# Altium schematic sheet CODEC.SchDoc(CODEC)
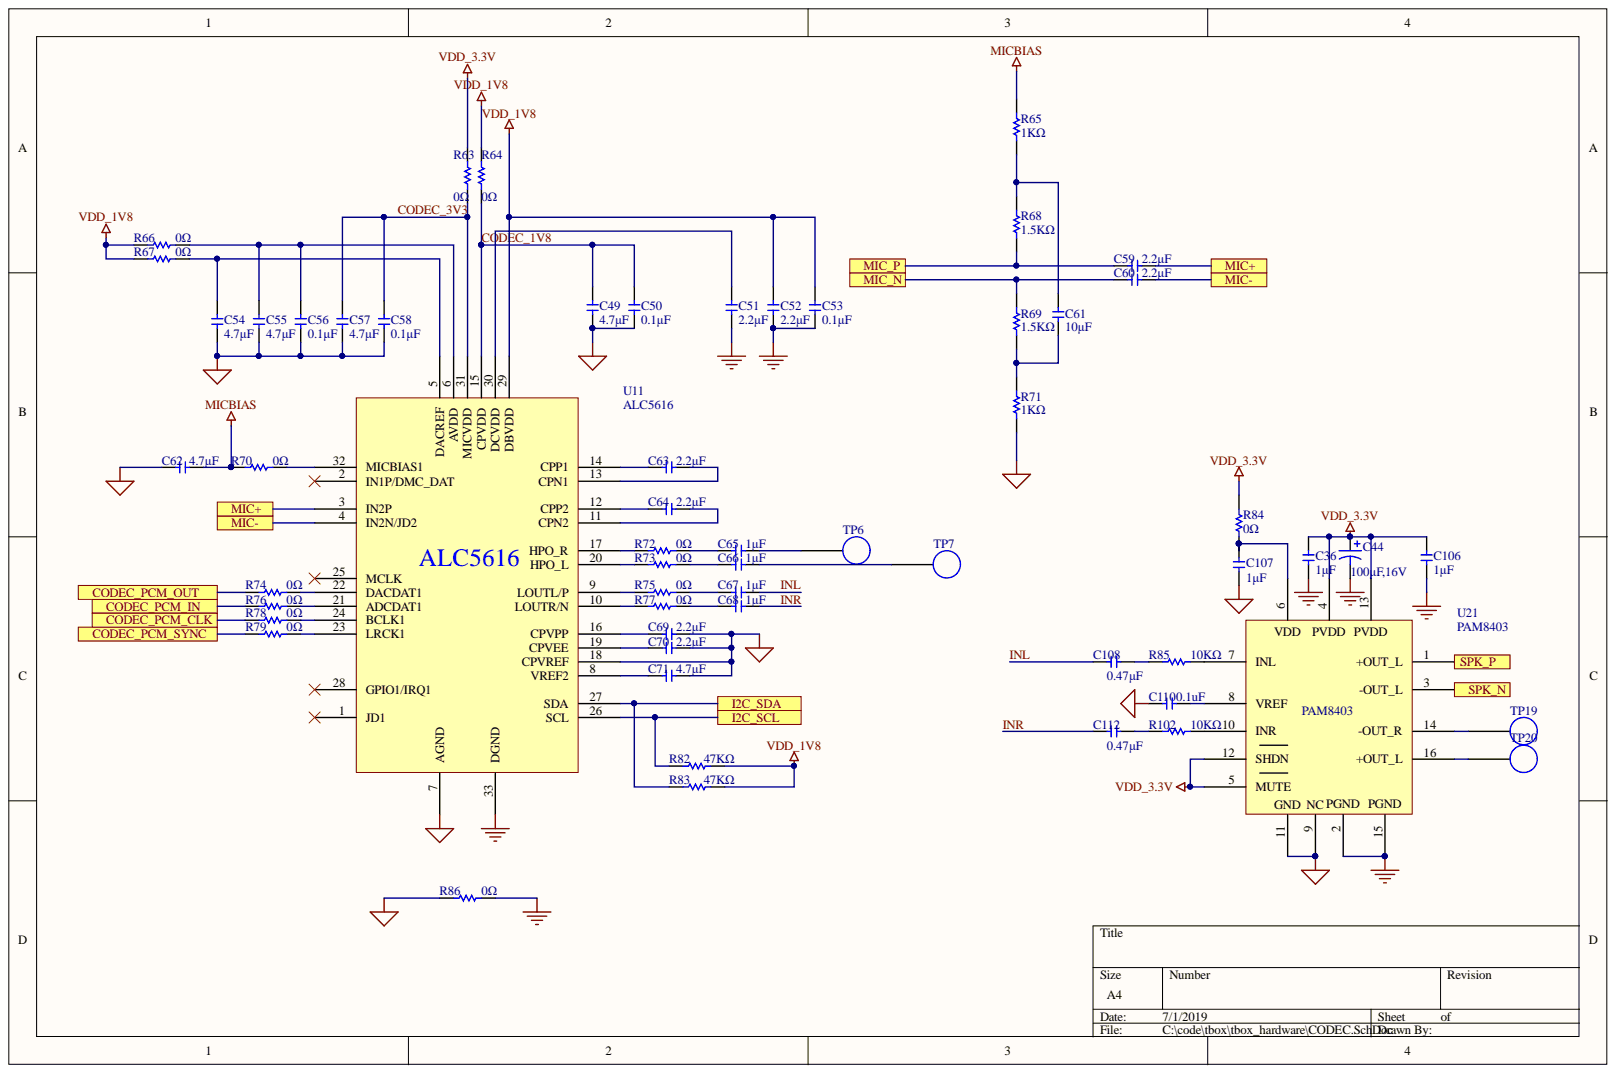
[diagram p0: CODEC.SchDoc]

## Parts
C36  1μF  [C0402]
C44  100μF,16V  [C1210]
C49  4.7μF  [C0402]
C50  0.1μF  [C0402]
C51  2.2μF  [C0402]
C52  2.2μF  [C0402]
C53  0.1μF  [C0402]
C54  4.7μF  [C0402]
C55  4.7μF  [C0402]
C56  0.1μF  [C0402]
C57  4.7μF  [C0402]
C58  0.1μF  [C0402]
C59  2.2μF  [C0402]
C60  2.2μF  [C0402]
C61  10μF  [C0805]
C62  4.7μF  [C0402]
C63  2.2μF  [C0402]
C64  2.2μF  [C0402]
C65  1μF  [C0402]
C66  1μF  [C0402]
C67  1μF  [C0402]
C68  1μF  [C0402]
C69  2.2μF  [C0402]
C70  2.2μF  [C0402]
C71  4.7μF  [C0402]
C106  1μF  [C0402]
C107  1μF  [C0402]
C108  0.47μF  [C0402]
C110  0.1uF  [C0402]
C112  0.47μF  [C0402]
R63  0Ω  [R0402]
R64  0Ω  [R0402]
R65  1KΩ  [R0402]
R66  0Ω  [R0402]
R67  0Ω  [R0402]
R68  1.5KΩ  [R0402]
R69  1.5KΩ  [R0402]
R70  0Ω  [R0402]
R71  1KΩ  [R0402]
R72  0Ω  [R0402]
R73  0Ω  [R0402]
R74  0Ω  [R0402]
R75  0Ω  [R0402]
R76  0Ω  [R0402]
R77  0Ω  [R0402]
R78  0Ω  [R0402]
R79  0Ω  [R0402]
R82  47KΩ  [R0402]
R83  47KΩ  [R0402]
R84  0Ω  [R0402]
R85  10KΩ  [R0402]
R86  0Ω  [R1206]
R102  10KΩ  [R0402]
TP6  TestPoint  [TP]  (value not drawn)
TP7  TestPoint  [TP]  (value not drawn)
TP19  TestPoint  [TP]  (value not drawn)
TP20  TestPoint  [TP]  (value not drawn)
U11  ALC5616  [QFN32_4x4]
U21  PAM8403  [SOP16]

## Ports
CODEC_PCM_CLK, CODEC_PCM_IN, CODEC_PCM_OUT, CODEC_PCM_SYNC, I2C_SCL, I2C_SDA, MIC+, MIC-, MIC_N, MIC_P, SPK_N, SPK_P

## Nets
AGND: C49.1 C50.1 C54.1 C55.1 C56.1 C57.1 C58.1 C62.1 C69.2 C70.2 C71.2 C107.2 C110.1 R71.1 R86.1 U11.7(AGND) U11.18(CPVREF) U21.9(NC) U21.11
GND: C36.2 C44.2 C51.1 C52.1 C53.1 C106.2 R86.2 U11.33(DGND) U21.2 U21.15
MICBIAS: C62.2 R65.2 R70.1
VDD_1V8: C52.2 C53.2 R64.2 R66.1 R67.1 R82.1 R83.1 U11.29(DBVDD)
VDD_3.3V: C36.1 C44.1 C106.1 R63.2 R84.2 U21.4 U21.5(MUTE) U21.12(SHDN) U21.13
CODEC_1V8: C49.2 C50.2 R64.1 U11.15(CPVDD)
CODEC_3V3: C57.2 C58.2 R63.1 U11.31(MICVDD)
CODEC_PCM_CLK: R78.1 ; port CODEC_PCM_CLK
CODEC_PCM_IN: R76.1 ; port CODEC_PCM_IN
CODEC_PCM_OUT: R74.1 ; port CODEC_PCM_OUT
CODEC_PCM_SYNC: R79.1 ; port CODEC_PCM_SYNC
I2C_SCL: R82.2 U11.26(SCL) ; port I2C_SCL
I2C_SDA: R83.2 U11.27(SDA) ; port I2C_SDA
INL: C67.2 C108.1
INR: C68.2 C112.1
MIC_N: C60.2 R69.2 ; port MIC_N
MIC_P: C59.2 R68.1 ; port MIC_P
SPK_N: U21.3(-OUT_L) ; port SPK_N
SPK_P: U21.1(+OUT_L) ; port SPK_P
NetC51_2: C51.2 U11.30(DCVDD)
NetC54_2: C54.2 R67.2 U11.5(DACREF)
NetC55_2: C55.2 C56.2 R66.2 U11.6(AVDD)
NetC59_1: C59.1 U11.3(IN2P)
NetC60_1: C60.1 U11.4(IN2N/JD2)
NetC61_1: C61.1 R69.1 R71.2
NetC61_2: C61.2 R65.1 R68.2
NetC63_1: C63.1 U11.14(CPP1)
NetC63_2: C63.2 U11.13(CPN1)
NetC64_1: C64.1 U11.12(CPP2)
NetC64_2: C64.2 U11.11(CPN2)
NetC65_1: C65.1 R72.2
NetC65_2: C65.2 TP6.1
NetC66_1: C66.1 R73.2
NetC66_2: C66.2 TP7.1
NetC67_1: C67.1 R75.2
NetC68_1: C68.1 R77.2
NetC69_1: C69.1 U11.16(CPVPP)
NetC70_1: C70.1 U11.19(CPVEE)
NetC71_1: C71.1 U11.8(VREF2)
NetC107_1: C107.1 R84.1 U21.6
NetC108_2: C108.2 R85.1
NetC110_2: C110.2 U21.8(VREF)
NetC112_2: C112.2 R102.1
NetR70_2: R70.2 U11.32(MICBIAS1)
NetR72_1: R72.1 U11.17(HPO_R)
NetR73_1: R73.1 U11.20(HPO_L)
NetR74_2: R74.2 U11.22(DACDAT1)
NetR75_1: R75.1 U11.9(LOUTL/P)
NetR76_2: R76.2 U11.21(ADCDAT1)
NetR77_1: R77.1 U11.10(LOUTR/N)
NetR78_2: R78.2 U11.24(BCLK1)
NetR79_2: R79.2 U11.23(LRCK1)
NetR85_2: R85.2 U21.7(INL)
NetR102_2: R102.2 U21.10(INR)
NetTP19_1: TP19.1 U21.14(-OUT_R)
NetTP20_1: TP20.1 U21.16(+OUT_L)

Not connected: U11 1(JD1) 2(IN1P/DMC_DAT) 25(MCLK) 28(GPIO1/IRQ1)

## Library data of the parts
C36 C49-C71 C106-C108 C110 C112 = Cap Semi: Capacitor (Semiconductor SIM Model); Simulation: CAP; Signal Integrity: Cap Semi; Published: 8-Jun-2000; PackageReference: C1206; PackageDescription: Chip Capacitor; Library Name: Miscellaneous Devices.SchLib; LatestRevisionNote: IPC-7351 Footprint Added.; LatestRevisionDate: 29-May-2009; Component Kind: Standard; Publisher: Altium Limited
  C36 C65-C68 C106 C107: Value: 1μF
  C49 C54 C55 C57 C62 C71: Value: 4.7μF
  C50 C53 C56 C58: Value: 0.1μF
  C51 C52 C59 C60 C63 C64 C69 C70: Value: 2.2μF
  C61: Value: 10μF
  C108 C112: Value: 0.47μF
  C110: Value: 0.1uF
C44 = Cap Pol2: Polarized Capacitor (Axial); Value: 100μF,16V; Simulation: CAP; Signal Integrity: Cap Pol; Published: 8-Jun-2000; PackageReference: POLAR0.8; PackageDescription: Polarized Capacitor; 2 Leads; Library Name: Miscellaneous Devices.SchLib; LatestRevisionNote: Re-released for DXP Platform.; LatestRevisionDate: 17-Jul-2002; Component Kind: Standard; Publisher: Altium Limited
R63-R79 R82-R86 R102 = Res1: Resistor; Simulation: RESISTOR; Signal Integrity: Res; Published: 8-Jun-2000; PackageReference: AXIAL-0.3; PackageDescription: Axial Device, Thru-Hole; 2 Leads; 0.3 in Pin Spacing; LatestRevisionNote: Re-released for DXP Platform.; LatestRevisionDate: 17-Jul-2002; Component Kind: Standard; Publisher: Altium Limited
  R63 R64 R66 R67 R70 R72-R79 R86: Value: 0Ω; Library Name: Miscellaneous Devices.SchLib
  R65 R71: Value: 1KΩ; Library Name: Miscellaneous Devices.SchLib
  R68 R69: Value: 1.5KΩ; Library Name: Miscellaneous Devices.SchLib
  R82 R83: Value: 47KΩ; Library Name: Miscellaneous Devices.SchLib
  R84: Value: 0Ω; Library Name: EC20_Project.SCHLIB
  R85 R102: Value: 10KΩ; Library Name: Miscellaneous Devices.SchLib
U11 = ALC5616: Ultra-Low Power Audio CODEC  for Mobile Devices; Library Name: Miscellaneous Devices.SchLib; Component Kind: Standard
U21 = PAM8403: AMP; Library Name: EC20_Project.SCHLIB; Component Kind: Standard
TP6 TP7 TP19 TP20 = TestPoint: Library Name: Miscellaneous Devices.SchLib; Component Kind: Standard
